# Altium schematic sheet PowerSupplies.SchDoc
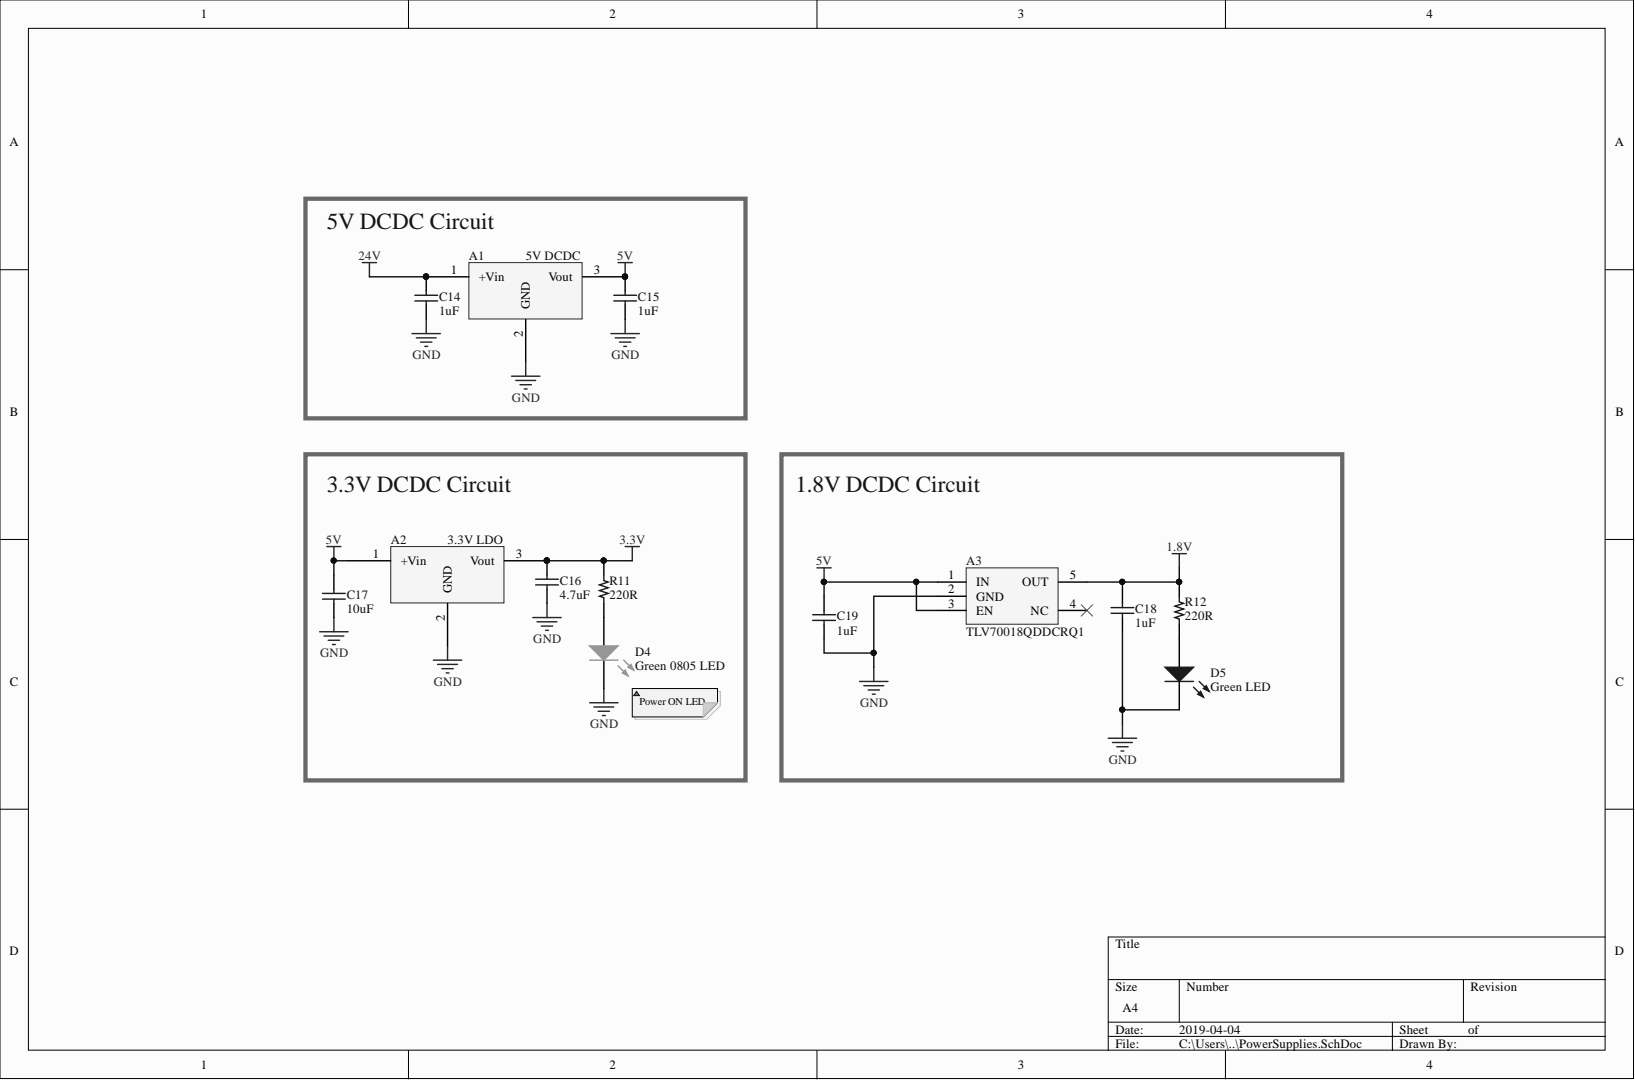
[diagram p0: PowerSupplies.SchDoc]

## Parts
A1  5V DCDC  Vout: 5V  [R-78E-0.5]
A2  3.3V LDO  Vout: 3.3V  [TO252-3]
A3  TLV70018QDDCRQ1  [SOT-23-5]
C14  value 1uF  [C0603]  (hidden comment: CAP CER 0603 1uF 25V X7R 20%)
C15  value 1uF  [C0603]  (hidden comment: CAP CER 0603 1uF 25V X7R 20%)
C16  value 4.7uF  [C0603]  (hidden comment: CAP CER 0603 4.7uF 25V X7R 10%)
C17  value 10uF  [C0603]  (hidden comment: CAP CER 0603 10uF 6.3V X5R 20%)
C18  value 1uF  [C0603]  (hidden comment: CAP CER 0603 1uF 16V X7R 10%)
C19  value 1uF  [C0603]  (hidden comment: CAP CER 0603 1uF 16V X7R 10%)
D4  Green 0805 LED  [LED0805]
D5  Green LED  [LED0603]
R11  value 220R  [R0603]  (hidden comment: RES 0603 220R 5% 0.25W)
R12  value 220R  [R0603]  (hidden comment: RES 0603 220R 5% 0.25W)

## Nets
1.8V: A3.5(OUT) C18.2 R12.2
3.3V: A2.3 C16.2 R11.2
5V: A1.3 A2.1 A3.1(IN) A3.3(EN) C15.2 C17.2 C19.2
24V: A1.1 C14.2
GND: A1.2 A2.2 A3.2(GND) C14.1 C15.1 C16.1 C17.1 C18.1 C19.1 D4.1 D5.1
NetD4_2: D4.2 R11.1
NetD5_2: D5.2 R12.1

Not connected: A3 4(NC)

## Library data of the parts
A1 = R78E5.0-0.5: Manufacturer: Recom; Part_Number: R-78E5.0-0.5; Efficiency: 82-92%; Iout: 500mA; Vin: 7-28V; Component Kind: Standard; Description: 5V DCDC; Library Name: Other.SchLib; Price: 4.04; Price_10: 3.972; Supplier_Link: http://www.digikey.ca/product-detail/en/R-78E5.0-0.5/945-1648-5-ND/2834904
A2 = NCV5501DT33RKG: Linear Voltage Regulator IC Positive Fixed 1 Output 3.3V 500mA DPAK-3; Manufacturer: ON Semiconductor; Component Kind: Standard; Iout: 500 mA; Library Name: IC.SchLib; Part_Number: NCV5501DT33RKG; Price: 1.33; Price_10: 1.194; Supplier 1: Digi-Key; Supplier_Link: http://www.digikey.ca/product-detail/en/on-semiconductor/NCV5501DT33RKG/NCV5501DT33RKGOSCT-ND/3462418; Supplier Part Number 1: NCV5501DT33RKGOSCT-ND
A3 = TLV70018QDDCRQ1: Linear Voltage Regulator IC Positive Fixed 1 Output 1.8V 300mA SOT-23-5; Manufacturer: Texas Instruments; Component Kind: Standard; Library Name: IC.SchLib; Part_Number: TLV70018QDDCRQ1; Supplier_Link: https://www.digikey.ca/product-detail/en/texas-instruments/TLV70018QDDCRQ1/296-36761-1-ND/4341463
C14 C15 = CAP CER 0603 1uF 25V X7R 20%: 1uF ceramic capacitor; Manufacturer: TDK Corporation; Part_Number: CGA3E1X7R1E105M080AC; Component Kind: Standard; Library Name: Capacitors.SchLib; Price: 0.24; Price_10: 0.171; Supplier_Link: http://www.digikey.ca/product-detail/en/CGA3E1X7R1E105M080AC/445-12548-1-ND/3954214; Tolerance: 20%; Voltage rating: 25V
C16 = CAP CER 0603 4.7uF 25V X7R 10%: 4.7uF ceramic capacitor; Manufacturer: Kernet; Part_Number: C1206C475K3RACAUTO; Component Kind: Standard; Library Name: Capacitors.SchLib; Price: 0.48; Price_10: 0.428; Supplier 1: Digi-Key; Supplier_Link: http://www.digikey.ca/product-detail/en/CGA3E3X7R1E474K080AB/445-6929-1-ND/2672947; Supplier Part Number 1: 399-7029-1-ND; Tolerance: 10%; Voltage rating: 25V
C17 = CAP CER 0603 10uF 6.3V X5R 20%: 10uF ceramic capacitor; Manufacturer: Taiyo Yuden; Part_Number: JMK107ABJ106MAHT; Component Kind: Standard; Library Name: Capacitors.SchLib; Price: 0.30; Price_10: 0.205; Supplier 1: Digi-Key; Supplier_Link: http://www.digikey.ca/product-detail/en/JMK107ABJ106MAHT/587-3383-1-ND/4157249; Supplier Part Number 1: 587-3383-1-ND; Tolerance: 20%; Voltage rating: 6.3V
C18 C19 = CAP CER 0603 1uF 16V X7R 10%: 1uF ceramic capacitor; Manufacturer: Samsung Electro-Mechanics; Part_Number: CL10B105KO8VPNC; Component Kind: Standard; Library Name: Capacitors.SchLib; Price: 0.32; Supplier 1: Digi-Key; Supplier_Link: https://www.digikey.ca/product-detail/en/samsung-electro-mechanics/CL10B105KO8VPNC/1276-6613-1-ND/5961472; Supplier Part Number 1: 1276-6613-1-ND; Tolerance: 10%; Voltage rating: 16V
D4 = APT2012LZGCK: Green 525nm LED Indication - Discrete 2.65V 0805 (2012 Metric); Manufacturer: Kingbright; Forward_Voltage: 2.65; Component Kind: Standard; Library Name: Diodes.SchLib; Part_Number: APT2012LZGCK; Price: 0.86; Price_10: 0.673; Supplier 1: Digi-Key; Supplier_Link: http://www.digikey.ca/product-detail/en/kingbright/APT2012LZGCK/754-1939-1-ND/5177469; Supplier Part Number 1: 754-1939-1-ND
D5 = 150060VS75000: Green LED 0603, 2V, 20mA; Manufacturer: Wurth Electronics; Component Kind: Standard; Library Name: Diodes.SchLib; Part_Number: 150060VS75000; Price: 0.34; Price_10: 0.3968; Supplier_Link: http://www.digikey.ca/product-detail/en/wurth-electronics-inc/150060VS75000/732-4980-1-ND/4489904
R11 R12 = RES 0603 220R 5% 0.25W: 220 Ohms 0.25W Resistor; Manufacturer: Rohm Semiconductor; Part_Number: ESR03EZPJ221; Component Kind: Standard; Library Name: Resistors.SchLib; Power: 0.25W; Price: 0.15; Supplier 1: Digi-Key; Supplier_Link: http://www.digikey.ca/product-detail/en/ESR03EZPJ221/RHM220DCT-ND/1983788; Supplier Part Number 1: RHM220DCT-ND; Tolerance: 5%
  R11: Price_10: 0.12
